# Altium schematic sheet Dual_motion_A1_R001.SchDoc(Dual_motion_A1_R001)
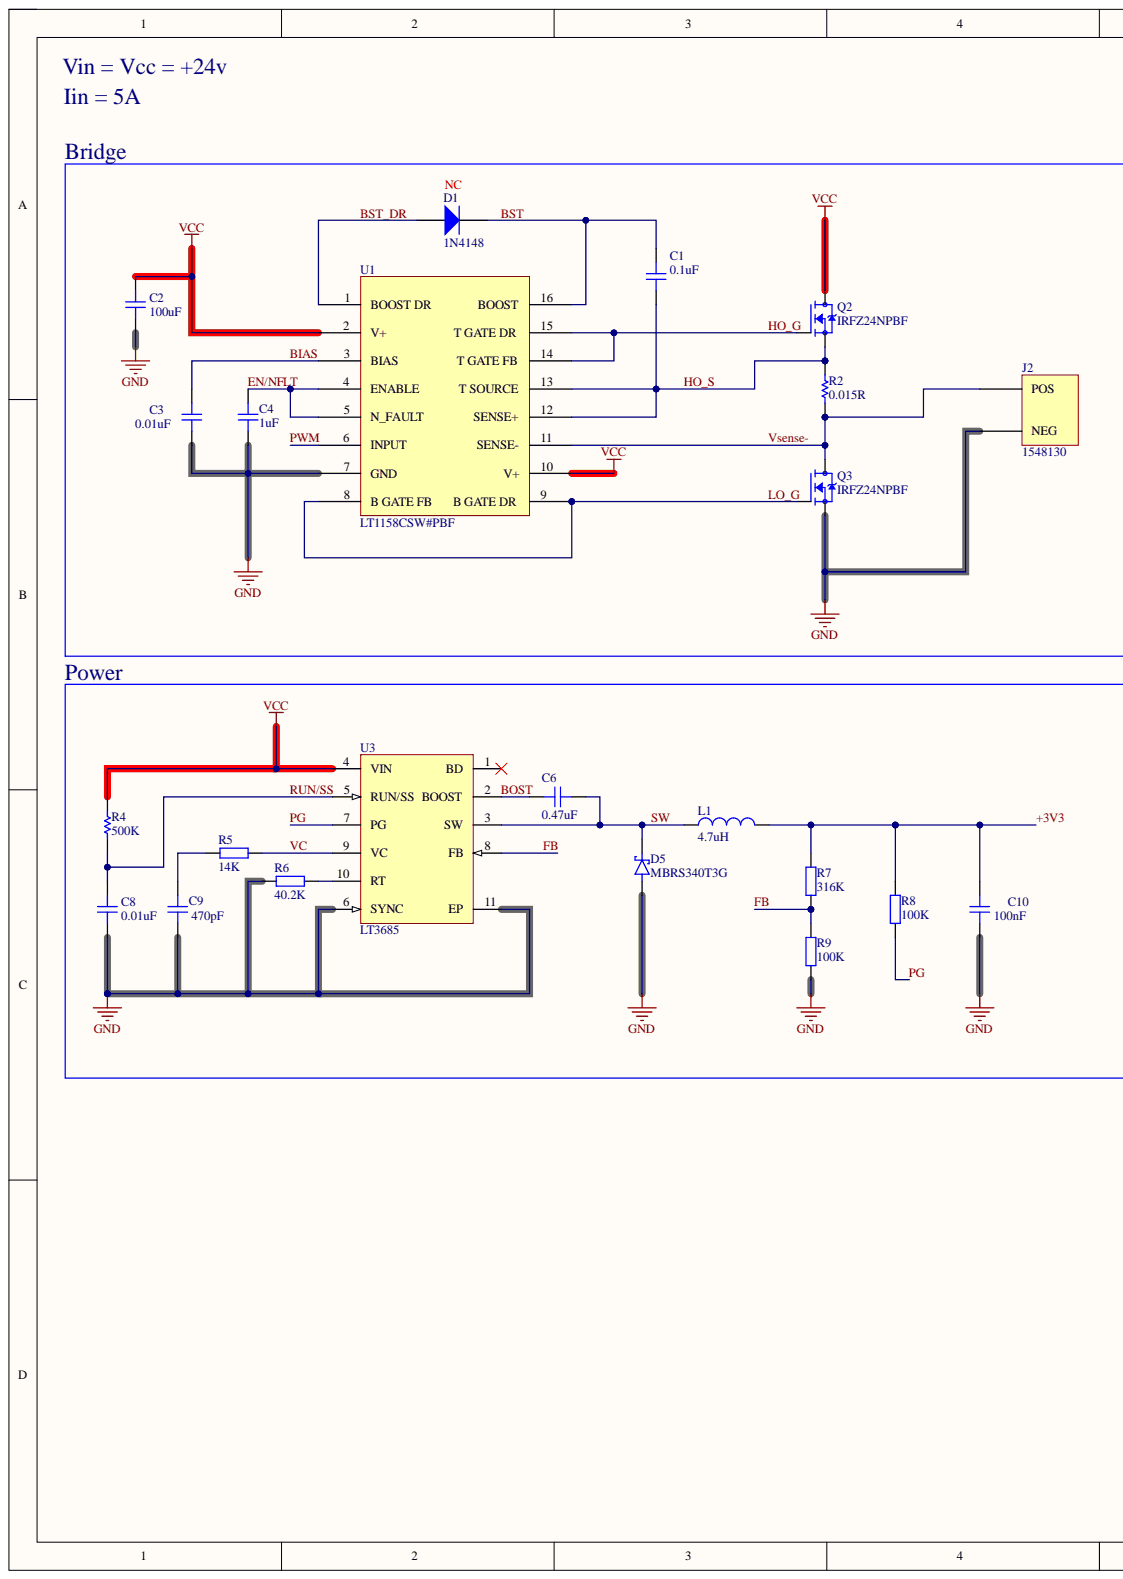
[diagram p0: Dual_motion_A1_R001.SchDoc, left]
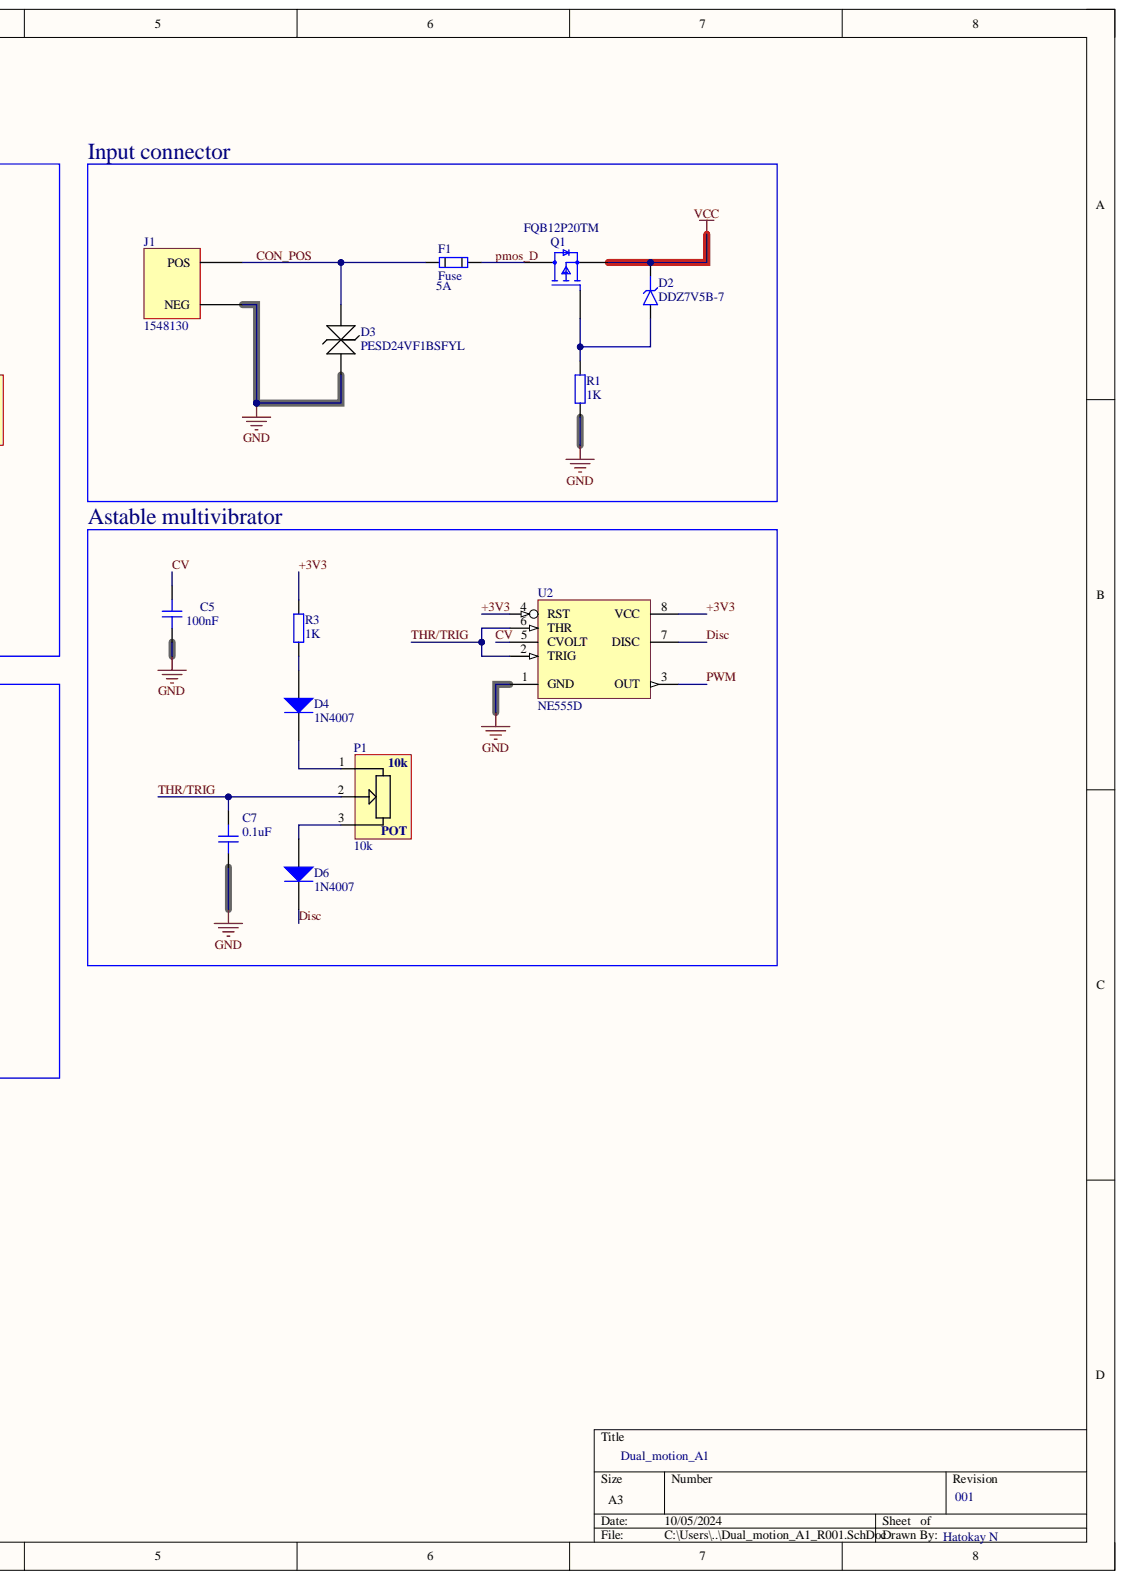
[diagram p1: Dual_motion_A1_R001.SchDoc, right]

## Parts
C1  0.1uF  [CAP_0402]
C2  100uF  [CAP_0402]
C3  0.01uF  [CAP_0402]
C4  1uF  [CAP_0402]
C5  100nF  [CAP_0402]
C6  0.47uF  [CAP_0402]
C7  0.1uF  [CAP_0402]
C8  0.01uF  [CAP_0402]
C9  470pF  [CAP_0402]
C10  100nF  [CAP_0402]
D1  1N4148  [DO-35]  (no BOM)
D2  DDZ7V5B-7  [SOD-323]
D3  PESD24VF1BSFYL  [PESD24VF1BSFYL]
D4  1N4007  [MY_1N4007_SMD]
D5  MBRS340T3G  [MBRS340T3G]
D6  1N4007  [MY_1N4007_SMD]
F1  Fuse  [FUSE-TH_XF-507P-A]
J1  1548130  [1548130]
J2  1548130  [1548130]
L1  4.7uH  [MY_0805]
P1  10k  [RV16AF-41-15R1-B10K]
Q1  FQB12P20TM  [TO-263-3]
Q2  IRFZ24NPBF  [IRFZ24NPBF]
Q3  IRFZ24NPBF  [IRFZ24NPBF]
R1  1K  [RES_0402]
R2  0.015R  [MY_0603]
R3  1K  [RES_0402]
R4  500K  [MY_0603]
R5  14K  [RES_0402]
R6  40.2K  [RES_0402]
R7  316K  [RES_0402]
R8  100K  [RES_0402]
R9  100K  [RES_0402]
U1  LT1158CSW#PBF  [LT1158CSW#PBF]
U2  NE555D  [D008_N]
U3  LT3685  [LT3685IDD]

## Nets
GND: C2.1 C3.1 C4.1 C5.1 C7.1 C8.1 C9.1 C10.1 D3.2 D5.A J1.02 J2.02 Q3.3 R1.1 R6.2 R9.1 U1.7(GND) U2.1(GND) U3.6(SYNC) U3.11(EP)
VCC: C2.2 D2.K Q1.3 Q2.2 R4.1 U1.2(V+) U1.10(V+) U3.4(VIN)
+3V3: C10.2 L1.2 R3.2 R7.2 R8.2 U2.4(RST) U2.8(VCC)
BIAS: C3.2 U1.3(BIAS)
BOST: C6.2 U3.2(BOOST)
BST: C1.2 D1.2 U1.16(BOOST)
BST_DR: D1.1 U1.1(BOOST_DR)
CON_POS: D3.1 F1.1 J1.01
CV: C5.2 U2.5(CVOLT)
Disc: D6.K U2.7(DISC)
EN/NFLT: C4.2 U1.4(ENABLE) U1.5(N_FAULT)
FB: R7.1 R9.2 U3.8(FB)
HO_G: Q2.1 U1.14(T_GATE_FB) U1.15(T_GATE_DR)
HO_S: C1.1 Q2.3 R2.1 U1.12(SENSE+) U1.13(T_SOURCE)
LO_G: Q3.1 U1.8(B_GATE_FB) U1.9(B_GATE_DR)
PG: R8.1 U3.7(PG)
pmos_D: F1.2 Q1.4
PWM: U1.6(INPUT) U2.3(OUT)
RUN/SS: C8.2 R4.2 U3.5(RUN/SS)
SW: C6.1 D5.C L1.1 U3.3(SW)
THR/TRIG: C7.2 P1.2 U2.2(TRIG) U2.6(THR)
VC: R5.1 U3.9(VC)
Vsense-: J2.01 Q3.2 R2.2 U1.11(SENSE-)
NetC9_2: C9.2 R5.2
NetD2_A: D2.A Q1.1 R1.2
NetD4_A: D4.A R3.1
NetD4_K: D4.K P1.1
NetD6_A: D6.A P1.3
NetR6_1: R6.1 U3.10(RT)

Not connected: U3 1(BD)

## Library data of the parts
C1 C7 = CAP_0402: Capacitor SMD 0402; Manufacturer: KYOCERA AVX; Manufacturer P/N: 04025C104MAT2A; Rating: 0.1uF 50VDC; Component Kind: Standard; Library Name: Schematic-Lib-PhilsLab.SchLib
C2 = CAP_0402: Tantalum Capacitors - Polymer POLYMER HERMSEAL; Manufacturer: Murata; Manufacturer P/N: TCH9107M035W0055L; Rating: 100uF 35VDC; Component Kind: Standard; Library Name: Schematic-Lib-PhilsLab.SchLib
C3 = CAP_0402: Multilayer Ceramic Capacitors MLCC - SMD/SMT 25V 10 000pF X7R 040 2 10% AEC-Q200; Manufacturer: KYOCERA AVX; Manufacturer P/N: KAM05AR71E103KH; Rating: 0.01uF 25VDC; Component Kind: Standard; Library Name: Schematic-Lib-PhilsLab.SchLib
C4 = CAP_0402: Multilayer Ceramic Capacitors MLCC - SMD/SMT 963-MSAST105SB5105MF RPLCMT PN 25V 1uF X5R 0402 20%; Manufacturer: TAIYO YUDEN; Manufacturer P/N: TMK105BJ105MV-F; Rating: 1uF 25VDC; Component Kind: Standard; Library Name: Schematic-Lib-PhilsLab.SchLib
C5 C10 = CAP_0402: Capacitor SMD 0402; Manufacturer: TAIYO YUDEN; Manufacturer P/N: MLAST105SB5104KFNA01; Component Kind: Standard; Library Name: Schematic-Lib-PhilsLab.SchLib
C6 = CAP_0402: Capacitor SMD 0402; Manufacturer: Murata Electronics; Manufacturer P/N: GRT155R6YA474KE01D; Component Kind: Standard; Library Name: Schematic-Lib-PhilsLab.SchLib
C8 = CAP_0402: Multilayer Ceramic Capacitors MLCC - SMD/SMT 25V 10 000pF X7R 040 2 10% AEC-Q200; Manufacturer: KYOCERA AVX; Manufacturer P/N: 04025C103K4T2A; Rating: 0.01uF 50VDC; Component Kind: Standard; Library Name: Schematic-Lib-PhilsLab.SchLib
C9 = CAP_0402: Capacitor SMD 0402; Manufacturer: KYOCERA AVX; Manufacturer P/N: 04025A471KAT2A; Rating: 470pF 50VDC; Component Kind: Standard; Library Name: Schematic-Lib-PhilsLab.SchLib
D1 = 1N4148: High Conductance Fast Diode; Code_JEDEC: DO-204-AH; ComponentLink1Description: Manufacturer Link; ComponentLink1URL: http://www.fairchildsemi.com/; ComponentLink2Description: Datasheet; ComponentLink2URL: http://www.fairchildsemi.com/ds/1N%2F1N4148.pdf; DatasheetDocument: 1997; LatestRevisionDate: 17-Jul-2002; LatestRevisionNote: Re-released for DXP Platform.; Library Name: FSC Discrete Diode.IntLib; PackageReference: DO-35; Published: 8-Jun-2000; Signal Integrity: 1N4148; Simulation: 1N4148; Publisher: Altium Limited
D2 = D_ZENER_SOD_323: Diode Zener SMD SOD-323; Manufacturer: Diodes Incorporated; Component Kind: Standard; Manufacturer P/N: DDZ7V5B-7; Library Name: Schematic-Lib-PhilsLab.SchLib
D3 = PESD24VF1BSFYL: ESD Protection Diodes / TVS Diodes SOD882BD Working Voltage: 24 V Clamping Voltage: 21 V Breakdown Voltage: 25 V; Manufacturer: Nexperia; Manufacturer P/N: PESD2V0Y1BSFYL; Component Kind: Standard; Library Name: Noah_LIB.IntLib
D4 D6 = 1N4007: 1 Amp Diode; ASiD Part Number: 1n4007-AS; Manufacturer: MULTICOMP PRO; Manufacturer P/N: 1N4007 SMD; Component Kind: Standard; Library Name: Mylib+Rev+4 (1).IntLib
D5 = MBRS340T3G: Diode, Schottky Barrier, Vr 40V, If 4A, Pkg SMC, Vf 0.5V, Tj +150degc, Cs 80A, Ir 20mA; Manufacturer: OnSemi; Component Kind: Standard; Manufacturer P/N: MBRS340T3G; Library Name: Noah_LIB.IntLib
F1 = XFCN - XF-507P-A: Plugin Fuse Holder ROHS  Car fuse holder; Manufacturer: XFCN; Component Kind: Standard; Manufacturer P/N: XF-507P-A; Library Name: Noah_LIB.IntLib
J1 J2 = 1548130 - 2 POS PIN: 2 port connector; Manufacturer: Phoenix Contact; Component Kind: Standard; Manufacturer P/N: 1548130; Library Name: Noah_LIB.IntLib
L1 = Inductor: ASiD Part Number: Inductor-AS; Manufacturer: TAIYO YUDEN; Manufacturer P/N: LBR2012T4R7M; Current: 1A; Component Kind: Standard; Description: Inductor; Library Name: Mylib+Rev+4 (1).IntLib; Value: 4.7uH
P1 = RV16AF-41-15R1-B10K: Potentiometer Vertical 10k THT Chassis-Mount 16mm; Manufacturer: Alpha; Component Kind: Standard; Manufacturer P/N: RV16AF-41-15R1-B10K; Library Name: Schematic-Lib-PhilsLab.SchLib
Q1 = FQB12P20TM: P-Channel Power MOSFET, QFET®, -200 V, -11.5 A, 470 mΩ, D2PAK; Manufacturer: onsemi; Component Kind: Standard; Manufacturer P/N: FQB12P20TM; Library Name: Noah_LIB.IntLib
Q2 Q3 = IRFZ24NPBF: MOSFET, Power,N-Ch,VDSS 55V,RDS(ON) 0.07Ohm,ID 17A,TO-220AB,PD 45W,VGS +/-20V | Infineon IRFZ24NPBF; Component Kind: Standard; Manufacturer: Infineon; Manufacturer P/N: IRFZ24NPBF; Library Name: Noah_LIB.IntLib
R1 R3 = RES_0402: Resistor SMD 0402; Manufacturer: Vishay / Draloric; Manufacturer P/N: TNPU04021K00BZEP00; Component Kind: Standard; Library Name: Schematic-Lib-PhilsLab.SchLib
R2 = Res: Standard resistor; ASiD Part Number: Res-AS; Manufacturer: Vishay; Manufacturer P/N: WFC0603R0150FE66; Component Kind: Standard; Library Name: Mylib+Rev+4 (1).IntLib; Value: 0.015R
R4 = Res: Standard resistor; ASiD Part Number: Res-AS; Manufacturer: YAGEO; Manufacturer P/N: RT0603BRD07505KL; Component Kind: Standard; Library Name: Mylib+Rev+4 (1).IntLib; Value: 500K
R5 = RES_0402: Resistor SMD 0402; Manufacturer: Vishay / Beyschlag; Manufacturer P/N: MCS04020D1402BE100; Component Kind: Standard; Library Name: Schematic-Lib-PhilsLab.SchLib
R6 = RES_0402: Resistor SMD 0402; Manufacturer: Panasonic; Manufacturer P/N: ERA-2ARB4022X; Component Kind: Standard; Library Name: Schematic-Lib-PhilsLab.SchLib
R7 = RES_0402: Resistor SMD 0402; Manufacturer: Vishay / Beyschlag; Manufacturer P/N: MCS04020C3163FE000; Component Kind: Standard; Library Name: Schematic-Lib-PhilsLab.SchLib
R8 R9 = RES_0402: Resistor SMD 0402; Manufacturer: Vishay; Manufacturer P/N: MCS0402MD1003BE000; Component Kind: Standard; Library Name: Schematic-Lib-PhilsLab.SchLib
U1 = LT1158CSW#PBF: Gate Drivers Half Bridge N-Ch Pwr MOSFET Drvr; Manufacturer: Analog Devices; Component Kind: Standard; Manufacturer P/N: LT1158CSW#PBF; Library Name: Noah_LIB.IntLib
U2 = NE555D: Precision Timer; Manufacturer: Texas Insruments; Manufacturer P/N: NE555DR; Code_IPC: SOIC127P600-8; Component Kind: Standard; ComponentLink1Description: Manufacturer Link; ComponentLink1URL: http://www.ti.com/; ComponentLink2Description: Datasheet; ComponentLink2URL: http://www-s.ti.com/sc/ds/ne555.pdf; DatasheetVersion: Feb-1992; LatestRevisionDate: 13-Apr-2006; LatestRevisionNote: IPC-7351 Footprint Added.; Library Name: TI Analog Timer Circuit.IntLib; PackageDescription: 8-Pin Small Outline Integrated Circuit 1.27 mm Pitch; PackageReference: D008; PackageVersion: Jan-1998; PCB3D: D008; Published: 16-Jan-2001; Publisher: Altium Limited
U3 = LT3685: LT3685 - 36V, 2A, 2.4MHz Step-Down Switching Regulator; Manufacturer: Analog devices; Manufacturer P/N: LT3685IDD#PBF; Component Kind: Standard; Library Name: Noah_LIB.IntLib
